# Altium schematic sheet DCDC.SchDoc(DCDC)
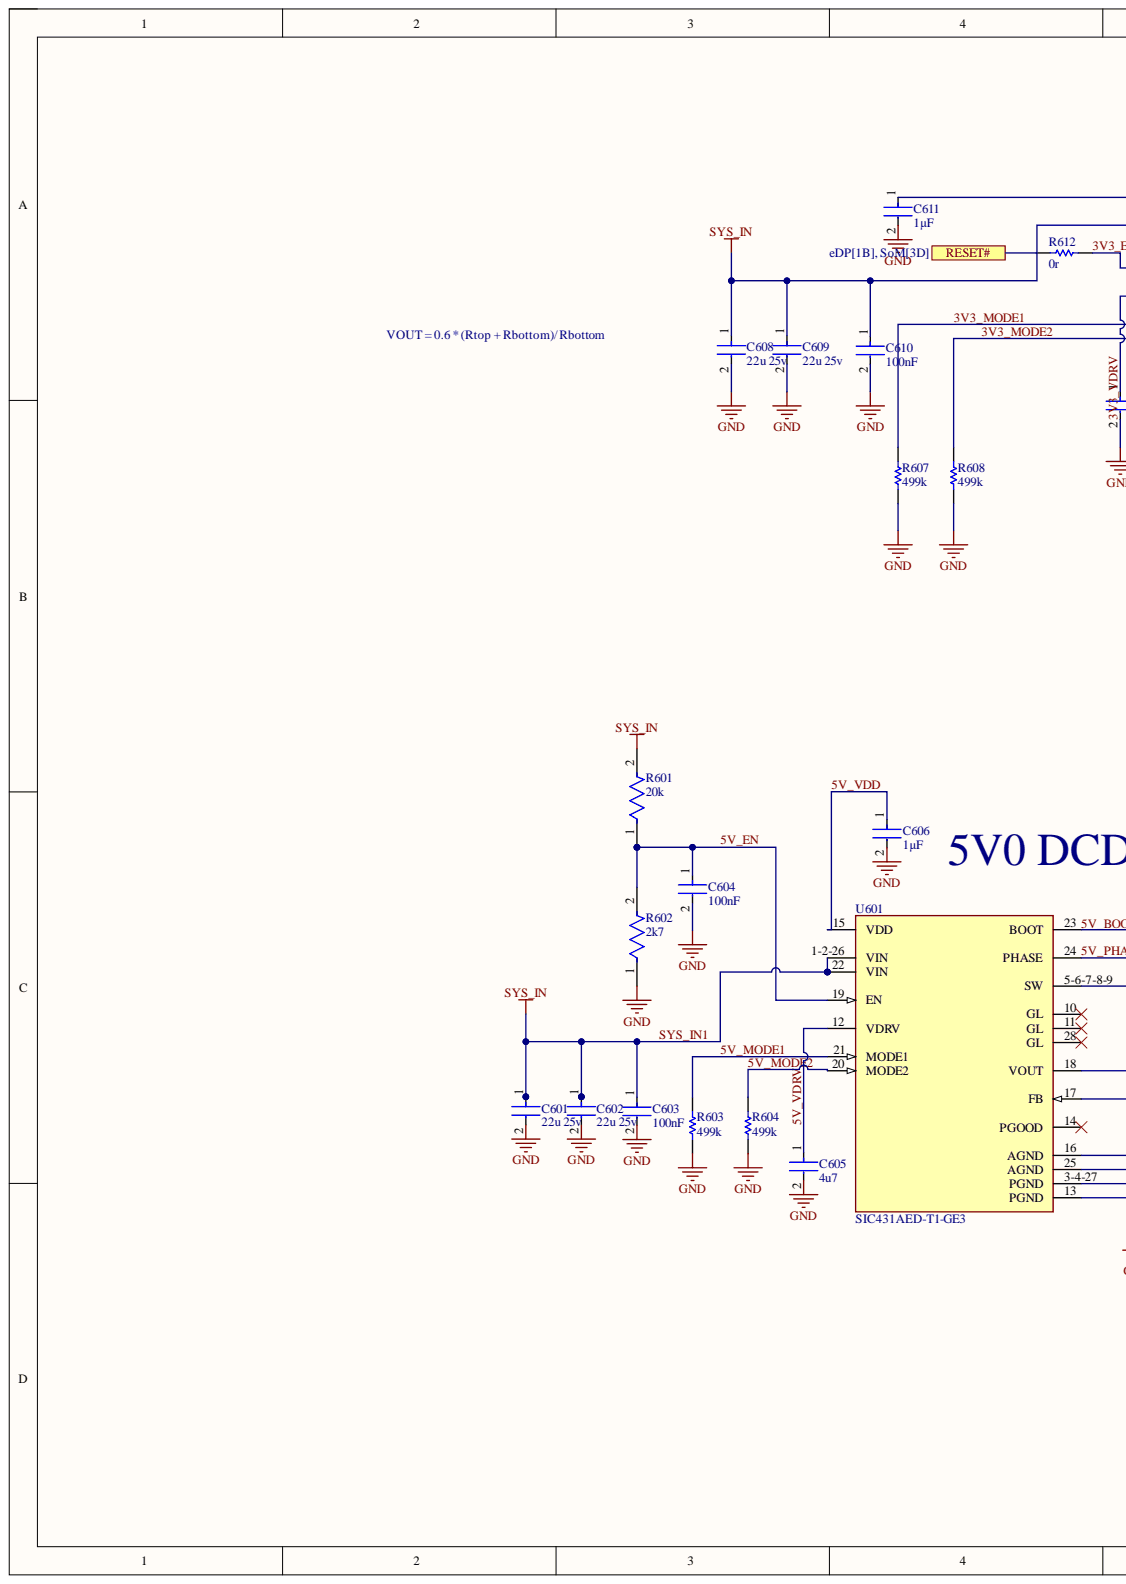
[diagram p0: DCDC.SchDoc, left]
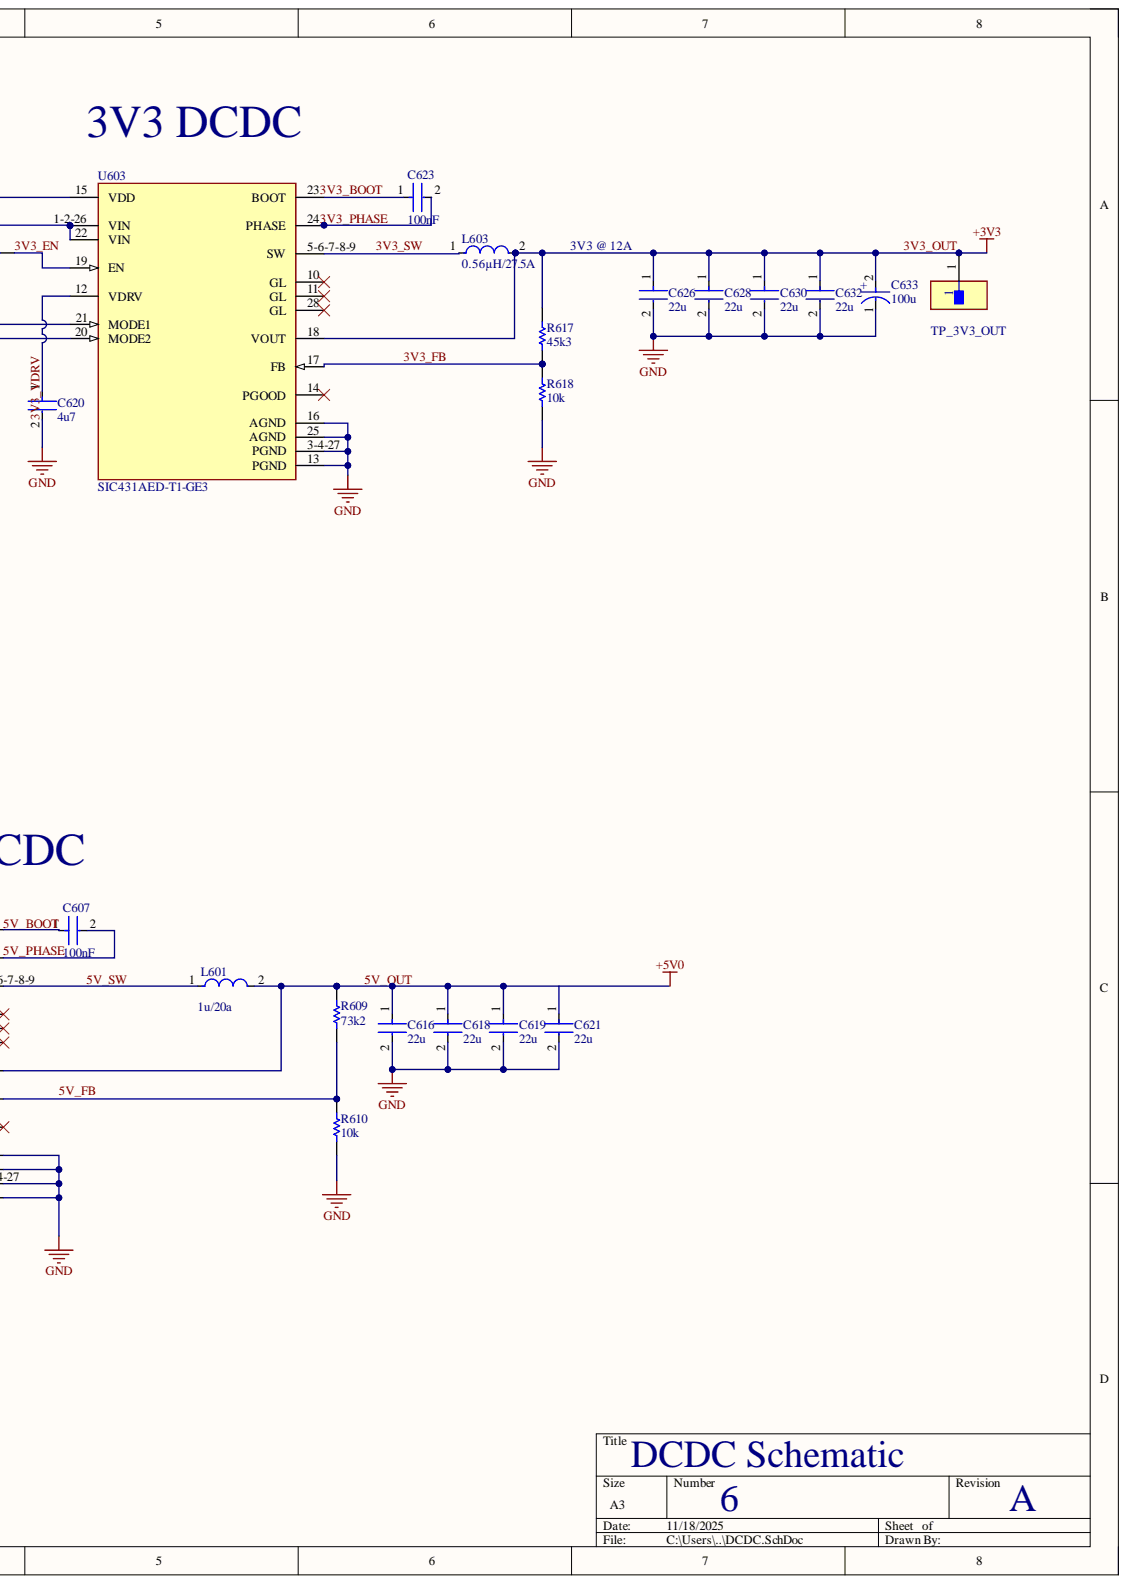
[diagram p1: DCDC.SchDoc, right]

## Parts
C601  22u 25v  [FP-GRM21B-0_2-e0_2_0_7-IPC_B]
C602  22u 25v  [FP-GRM21B-0_2-e0_2_0_7-IPC_B]
C603  100nF  [FP-GRM155-0_05-IPC_B]
C604  100nF  [FP-GRM155-0_05-IPC_B]
C605  4u7  [FP-GRM155-0_2-MFG]
C606  1µF  [FP-GRM15-0_1-MFG]
C607  100nF  [FP-GRM155-0_05-IPC_B]
C608  22u 25v  [FP-GRM21B-0_2-e0_2_0_7-IPC_B]
C609  22u 25v  [FP-GRM21B-0_2-e0_2_0_7-IPC_B]
C610  100nF  [FP-GRM155-0_05-IPC_B]
C611  1µF  [FP-GRM15-0_1-MFG]
C616  22u  [FP-CL10-IPC_B]
C618  22u  [FP-CL10-IPC_B]
C619  22u  [FP-CL10-IPC_B]
C620  4u7  [FP-GRM155-0_2-MFG]
C621  22u  [FP-CL10-IPC_B]
C623  100nF  [FP-GRM155-0_05-IPC_B]
C626  22u  [FP-CL10-IPC_B]
C628  22u  [FP-CL10-IPC_B]
C630  22u  [FP-CL10-IPC_B]
C632  22u  [FP-CL10-IPC_B]
C633  100u  [FP-OS-CON-SMT-B6-MFG]
L601  1u/20a  [FP-SRP6050CA-MFG]
L603  0.56µH/27.5A  [FP-IHLP-4040DZ-FP_3_175x4-MFG]
R601  20k  [FP-CR0402-IPC_A]
R602  2k7  [FP-CR0402-IPC_B]
R603  499k  [RESC1005X40X25NL10T10]
R604  499k  [RESC1005X40X25NL10T10]
R607  499k  [RESC1005X40X25NL10T10]
R608  499k  [RESC1005X40X25NL10T10]
R609  73k2  [RESC1005X40X25LL10T10]
R610  10k  [RESC1005X40X25LL05T10]
R612  0r  [RESC1005X40X25LL05T05]
R617  45k3  [RESC1005X40X25ML05T10]
R618  10k  [RESC1005X40X25LL05T10]
TP603  TP_3V3_OUT  [FP-S2751-46R-MFG]
U601  SIC431AED-T1-GE3  [FP-T22-0442-MFG]
U603  SIC431AED-T1-GE3  [FP-T22-0442-MFG]

## Ports
RESET#

## Nets
3V3_EN: R612.2 U603.19(EN)
3V3_FB: R617.1 R618.2 U603.17(FB)
3V3_MODE1: R607.2 U603.21(MODE1)
3V3_MODE2: R608.2 U603.20(MODE2)
3V3_OUT: C626.1 C628.1 C630.1 C632.1 L603.2 R617.2 TP603.1 U603.18(VOUT)
3V3_PHASE: C623.2 U603.24(PHASE)
3V3_VDRV: C601.2 C602.2 C603.2 C604.2 C605.2 C606.2 C608.2 C609.2 C610.2 C611.2 C616.2 C618.2 C619.2 C620.2 C621.2 C626.2 C628.2 C630.2 C632.2 C633.1 R602.1 R603.1 R604.1 R607.1 R608.1 R610.1 R618.1 U601.3-4-27(PGND) U601.13(PGND) U601.16(AGND) U601.25(AGND) U603.3-4-27(PGND) U603.13(PGND) U603.16(AGND) U603.25(AGND)
5V_EN: C604.1 R601.1 R602.2 U601.19(EN)
5V_MODE1: R603.2 U601.21(MODE1)
5V_MODE2: R604.2 U601.20(MODE2)
5V_OUT: C616.1 C618.1 C619.1 C621.1 L601.2 R609.2 U601.18(VOUT)
5V_PHASE: C607.2 U601.24(PHASE)
5V_SW: L601.1 U601.5-6-7-8-9(SW)
5V_VDD: C606.1 U601.15(VDD)
5V_VDRV: C605.1 U601.12(VDRV)
RESET#: R612.1 ; port RESET#
SYS_IN1: C601.1 C602.1 C603.1 C608.1 C609.1 C610.1 R601.2 U601.1-2-26(VIN) U601.22(VIN) U603.1-2-26(VIN) U603.22(VIN)
NetC607_1: C607.1 U601.23(BOOT)
NetC611_1: C611.1 U603.15(VDD)
NetC620_1: C620.1 U603.12(VDRV)
NetC623_1: C623.1 U603.23(BOOT)
NetL603_1: L603.1 U603.5-6-7-8-9(SW)
NetR609_1: R609.1 R610.2 U601.17(FB)
3V3_BOOT:
3V3_SW:
5V_BOOT:
5V_FB:

Not connected: C633 2; U601 10(GL) 11(GL) 14(PGOOD) 28(GL); U603 10(GL) 11(GL) 14(PGOOD) 28(GL)

## Library data of the parts
C601 C602 C608 C609 = CMP-2007-03222-3: Chip Multilayer Ceramic Capacitors for General Purpose, 0805, 22uF, X5R, 15%, 20%, 25V; Capacitance: 22uF; Case Code (Imperial): 0805; Case Code (Metric): 2012; Case/Package: 0805; Component Kind: Standard; Depth: 1.25mm; Dielectric: X5R; Height: 1.25mm; Lead Free: Lead Free; Length: 2mm; Library Name: Altium Content Vault; Material: Ceramic; Max Operating Temperature: 85°C; Min Operating Temperature: -55°C; Mount: Surface Mount; Package Quantity: 3000; Packaging: Tape and Reel; REACH SVHC: No SVHC; Resistor Type: Ceramic; Termination: SMD/SMT; Thickness: 0.057inch; Tolerance: 20%; Voltage: 25V; Voltage Rating: 25V; Voltage Rating (DC): 25V; Weight: 0.000335oz; Width: 1.25mm
C603 C604 C607 C610 C623 = CMP-2008-04639-2: Chip Multilayer Ceramic Capacitors for General Purpose, 0402, 0.10uF, X7R, 15%, 10%, 50V; Dissipation Factor: 0.025%; Capacitance: 100nF; Case Code (Imperial): 0402; Case Code (Metric): 1005; Case/Package: 0402; Component Kind: Standard; Depth: 0.5mm; Dielectric: X7R; Dielectric Material: Ceramic; Height: 0.5mm; Lead Free: Lead Free; Length: 1mm; Library Name: Altium Content Vault; Material: Ceramic; Max Operating Temperature: 125°C; Min Operating Temperature: -55°C; Mount: Surface Mount; Package Quantity: 10000; Packaging: Tape and Reel; REACH SVHC: No SVHC; Resistor Type: Ceramic; Termination: SMD/SMT; Thickness: 0.022inch; Tolerance: 10%; Voltage: 50V; Voltage Rating: 50V; Voltage Rating (DC): 50V; Weight: 0.000212oz; Width: 0.5mm
C605 C620 = CMP-06035-063317-1: Chip Multilayer Ceramic Capacitors for General Purpose 0402 Package 0.7mm 4.7uF @ 20% 25Vdc X5S @ 22%; Component Kind: Standard; Library Name: Altium Content Vault
C606 C611 = CMP-2008-04556-2: CAP CER 1UF 10V X5R 0402; Manufacturer: Murata; Manufacturer Part Number: GRM155R61A105KE15D; Material: Ceramic; Temperature Coefficient: 15%; Tolerance: 10%; Automotive: No; Capacitance: 1uF; Category: Cap; Component Kind: Standard; ComponentLink2Description: Datasheet; ComponentLink2URL: https://search.murata.co.jp/Ceramy/image/img/A01X/G101/ENG/GRM155R61A105KE15-01A.pdf; Device Class L1: Passive Components; Device Class L2: Capacitors; Device Class L3: Ceramic Capacitors; Digikey Description: CAP CER 1UF 10V X5R 0402; Height: 0.55mm; Ipc Land Pattern Name: CAPC10050X50; Lead Free: Yes; Library Name: Altium Content Vault; Mouser Description: 0402 1 uF 10 V ±10% Tolerance X5R Surface Mount Multilayer Ceramic Capacitor; Octopart: https://octopart.com/grm155r61a105ke15d-murata-197442; Package: GRM15-0.1; RoHS: TRUE; Temperature: -55°C to +85°C; Temperature Characteristic: X5R; Temperature Range High: +85°C; Temperature Range Low: -55°C; Value: 1uF; Voltage Rating: 10V
C616 C618 C619 C621 C626 C628 C630 C632 = CMP-13271-002755-1: Cap Ceramic 22uF 16V X5R ±20% Pad SMD 0603 +85°C T/R; Capacitance: 22uF; Case Code (Imperial): 0603; Case Code (Metric): 1608; Case/Package: 0603; Component Kind: Standard; Library Name: Altium Content Vault; Max Operating Temperature: 85°C; Min Operating Temperature: -55°C; Mount: Surface Mount; Packaging: Tape and Reel; Tolerance: 20%; Voltage Rating: 16V
C633 = CMP-05428-000099-1: Conductive Polymer Aluminum Solid Capacitors (OS-CON) 100uF ±20% 6.3V; Dissipation Factor: 12%; ESR (Equivalent Series Resistance): 30mR; Leakage Current: 300uA; Life (Hours): 2000Hour; Polarity: Polar; Ripple Current: 1.97A; Capacitance: 100uF; Case/Package: Radial; Component Kind: Standard; Construction: Cylindrical; Diameter: 5mm; Height: 5.9mm; Height - Seated (Max): 0.236inch; Lead Free: Lead Free; Length: 5.9mm; Library Name: Altium Content Vault; Max Operating Temperature: 105°C; Min Operating Temperature: -55°C; Mount: Surface Mount; Number of Pins: 6; Package Quantity: 1500; Packaging: Tape and Reel; REACH SVHC: No SVHC; Ripple Current (AC): 1.97A; RoHS Compliant: Yes; Series: OS-CON™, SVPC; Termination: SMD/SMT; Tolerance: 20%; Voltage: 6.3V; Voltage Rating: 6.3V; Voltage Rating (DC): 6.3V
L601 = CMP-07248-000017-1: FIXED IND 1UH 20A 4.52 MOHM SMD; Manufacturer: Bourns; Manufacturer Part Number: SRP6050CA-1R0M; Automotive Grade: Grade 0; Core Material: Metal alloy powder; Saturation Current: 23A; Self Resonant Frequency: 50MHz; Series Resistance: 4.52mΩ; Shielding: true; Test Frequency: 100kHz; Tolerance: 20%; Automotive: Yes; Category: Ind; Component Kind: Standard; ComponentLink2Description: Datasheet; ComponentLink2URL: https://www.bourns.com/docs/Product-Datasheets/SRP6050CA.pdf; Composition: Wirewound; Device Class L1: Passive Components; Device Class L2: Inductors; Device Class L3: Fixed Inductors; Digikey Description: FIXED IND 1UH 20A 4.52 MOHM SMD; Height: 5mm; Inductance: 1uH; Lead Free: Yes; Library Name: Altium Content Vault; Mouser Description: Inductor Power Shielded Wirewound 1uH 20% 100KHz Metal Alloy Powder 20A 4.52mOhm DCR T/R; Octopart: https://octopart.com/srp6050ca-1r0m-bourns-92222725; Package: SRP6050CA; Rms Current: 20A; RoHS: TRUE; Temperature: -55°C to +155°C; Temperature Range High: +155°C; Temperature Range Low: -55°C; Value: 1uH
L603 = CMP-02424-000366-1: Commercial Inductors, High Saturation Series 0.56uH 27.5A 1.8mΩ 20%; Core Material: Metal; DC Resistance (DCR): 1.8mR; Inductance: 560nH; Max DC Current: 49A; Self Resonant Frequency: 5MHz; Shielding: Shielded; Test Frequency: 100kHz; Case Code (Imperial): 4040; Case/Package: 4040; Component Kind: Standard; Contact Plating: Tin; Current Rating: 27.5A; Depth: 10.3mm; Height: 4mm; Inductance Tolerance: 20%; Lead Free: Lead Free; Length: 10.92mm; Library Name: Altium Content Vault; Max Operating Temperature: 125°C; Military Standard: Not; Min Operating Temperature: -55°C; Mount: Surface Mount; Number of Pins: 2; Package Quantity: 500; Packaging: Tape and Reel; Radiation Hardening: No; RMS Current (Irms): 27.5A; Saturation Current: 49A; Termination: SMD/SMT; Tolerance: 20%; Weight: 0.070548oz; Width: 10.3mm
R601 = CMP-1011-00655-3: Thick Film Chip Resistors 0402 20kΩ 0.0625W 1% 100ppm/°C; Power Rating: 62.5mW; Resistance: 20kR; Voltage Rating: 50V; Case Code (Imperial): 0402; Case Code (Metric): 1005; Case/Package: 0402; Component Kind: Standard; Composition: Thick Film; Contact Plating: Tin; Depth: 0.5mm; Height: 0.35mm; Length: 1mm; Library Name: Altium Content Vault; Max Operating Temperature: 125°C; Military Standard: Not; Min Operating Temperature: -55°C; Mount: Surface Mount; Package Quantity: 10000; Packaging: Tape and Reel; Radiation Hardening: No; Temperature Coefficient: 100ppm/°C; Termination: SMD/SMT; Thickness: 356um; Tolerance: 1%; Width: 0.5mm
R602 = CMP-2002-05009-2: Thick Film Chip Resistors 0402 2.7kΩ 0.0625W 1% 100ppm/°C; Max Power Dissipation: 63mW; Power Rating: 62.5mW; Resistance: 2.7kR; Voltage Rating: 50V; Case Code (Imperial): 0402; Case Code (Metric): 1005; Case/Package: 0402; Component Kind: Standard; Composition: Thick Film; Contact Plating: Tin; Depth: 0.5mm; Height: 0.016inch; Length: 1mm; Library Name: Altium Content Vault; Max Operating Temperature: 125°C; Military Standard: Not; Min Operating Temperature: -55°C; Mount: Surface Mount; Number of Terminations: 2; Package Quantity: 10000; Packaging: Tape and Reel; Radiation Hardening: No; Temperature Coefficient: 100ppm/°C; Termination: SMD/SMT; Thickness: 356um; Tolerance: 1%; Width: 0.02inch
R603 R604 R607 R608 = CMP-1011-00815-2: Manufacturer: Bourns; Part Number: CR0402-FX-4993GLF; Component Kind: Standard; Library Name: Altium Content Vault
R609 = CMP-2002-05384-1: Manufacturer: Bourns; Part Number: CR0402-FX-7322GLF; Component Kind: Standard; Library Name: Altium Content Vault
R610 R618 = CMP-2002-07353-1: Manufacturer: Yageo / Phycomp; Part Number: RC0402FR-0710KL; Component Kind: Standard; Library Name: Altium Content Vault
R612 = CMP-2000-07451-1: Chip Resistor, 0 Ohm, 0.1 W, -55 to 155 degC, 0402 (1005 Metric), RoHS, Tape and Reel; Manufacturer: Panasonic; Max Power Dissipation: 100mW; Resistance: 0mOhm; Temperature Coefficient: 600ppm/��C; Tolerance: 5%; Voltage Rating: 50V; Case Code (Imperial): 0402; Case Code (Metric): 1005; Case/Package: 0402; Component Kind: Standard; Composition: ThickFilm; Contact Plating: Tin; Datasheet URL: https://industrial.panasonic.com/cdbs/www-data/pdf/RDA0000/AOA0000C301.pdf; Datasheet Version: 03/2015; Depth: 0.5mm; Height: 0.35mm; Lead Free: LeadFree; Length: 1mm; Library Name: Altium Content Vault; Manufacturer URL: http://www.panasonic.com/; Max Operating Temperature: 155degC; Military Standard: Not; Min Operating Temperature: -55degC; Mount: SurfaceMount; Mounting Technology: SurfaceMount; Number of Terminations: 2; Package Description: 2-Pin Surface Mount Device, Body 1 x 0.5 mm; Package Quantity: 1; Package Reference: 402; Packaging: TapeandReel; Power Rating: 100mW; Radiation Hardening: No; REACH SVHC: NoSVHC; RoHSCompliant: True; Termination: SMD/SMT; Voltage Rating (DC): 50V; Width: 0.02inch
R617 = CMP-2002-07692-1: Manufacturer: Yageo / Phycomp; Part Number: RC0402FR-0745K3L; Component Kind: Standard; Library Name: Altium Content Vault
TP603 = CMP-15817-000010-1: PC TEST POINT NATURAL; Body Material: Brass; Plating: Tin; Color: Natural; Component Kind: Standard; Library Name: Altium Content Vault; Material: Copper Alloy; Mount: Surface Mount; Packaging: Cut Tape
U601 U603 = CMP-00030-87948104-1: SIC431AED-T1-GE3 IC Reg Buck Adj 24A MLP44-24; Max Junction Temperature (Tj): 125°C; Max Supply Voltage: 24V; Min Supply Voltage: 3V; Number of Outputs: 1; Output Voltage: 20V; Ambient Temperature Range High: 105°C; Component Kind: Standard; Height: 800µm; Library Name: Altium Content Vault; Max Operating Temperature: 125°C; Min Operating Temperature: -40°C; NexarPartId: 87948104; Output Current: 24A; RoHS: Non-Compliant; Schedule B: 8542390000, 8542390000
